# Altium schematic sheet driver0-3.SchDoc(driver0-3)
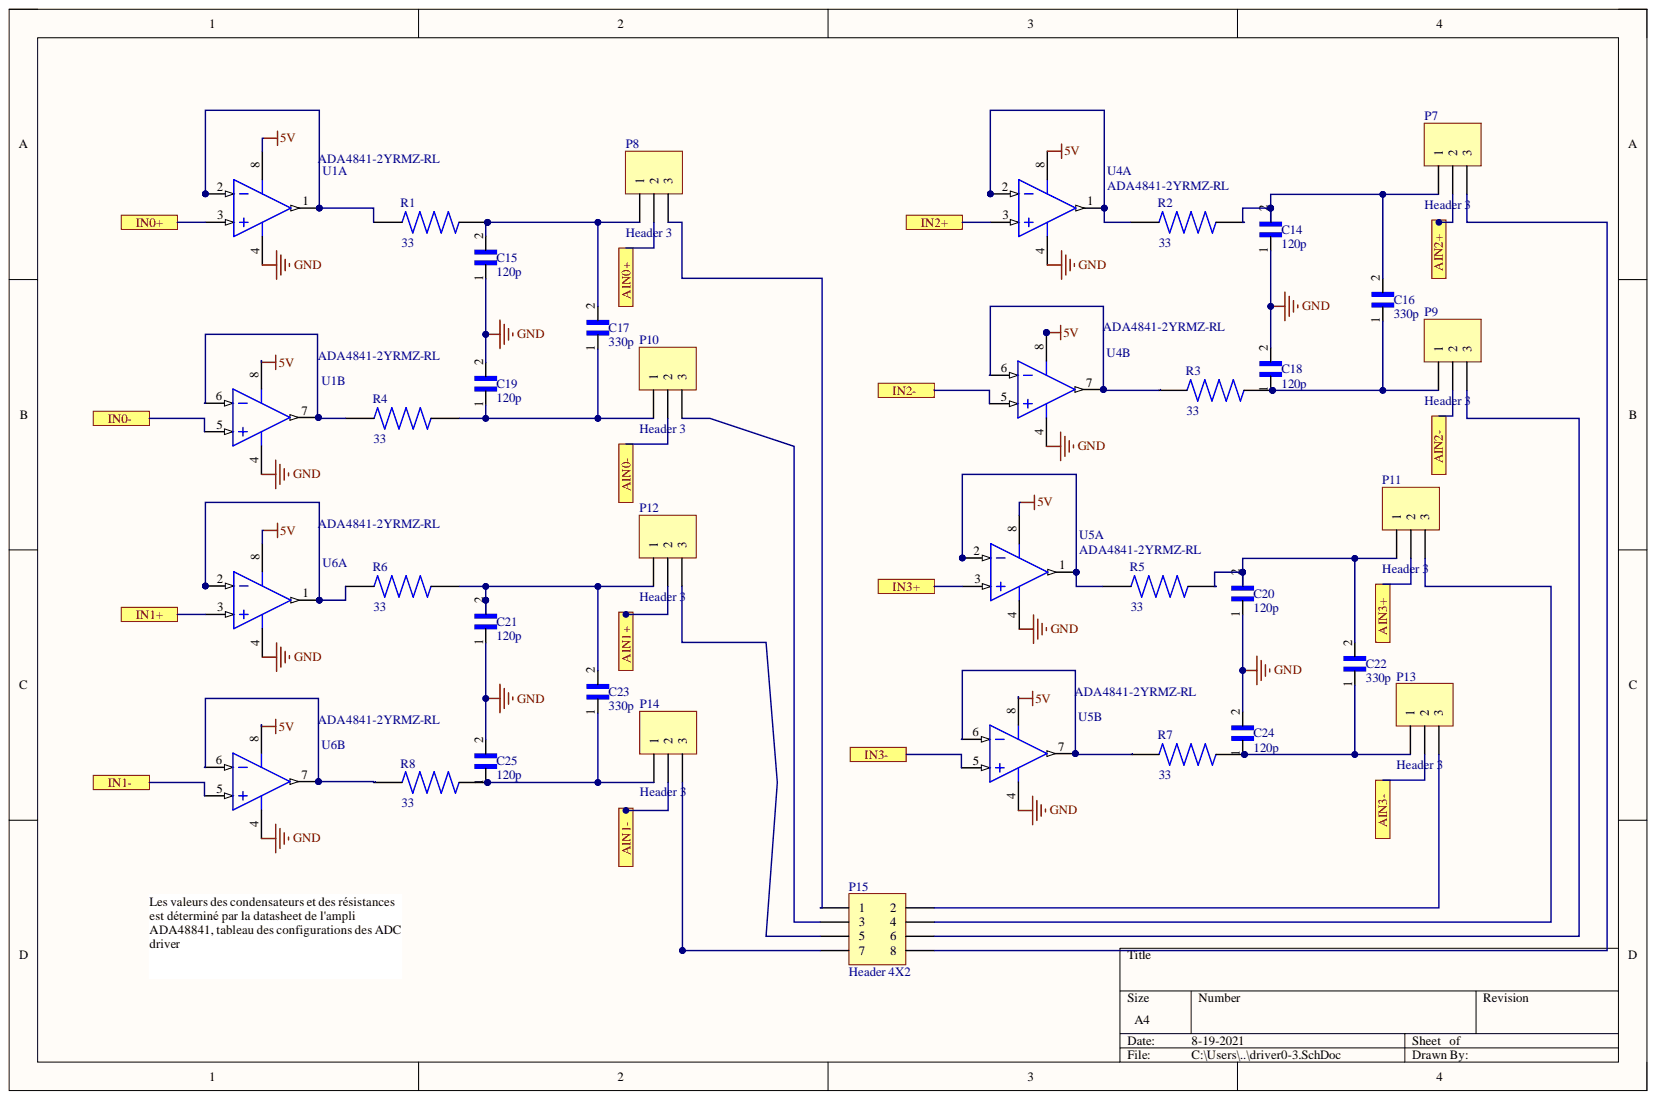
[diagram p0: driver0-3.SchDoc]

## Parts
C14  120p  [CAPC3216X94N]
C15  120p  [CAPC3216X94N]
C16  330p  [CAPC3216X94N]
C17  330p  [CAPC3216X94N]
C18  120p  [CAPC3216X94N]
C19  120p  [CAPC3216X94N]
C20  120p  [CAPC3216X94N]
C21  120p  [CAPC3216X94N]
C22  330p  [CAPC3216X94N]
C23  330p  [CAPC3216X94N]
C24  120p  [CAPC3216X94N]
C25  120p  [CAPC3216X94N]
P7  Header 3  [HDR1X3]
P8  Header 3  [HDR1X3]
P9  Header 3  [HDR1X3]
P10  Header 3  [HDR1X3]
P11  Header 3  [HDR1X3]
P12  Header 3  [HDR1X3]
P13  Header 3  [HDR1X3]
P14  Header 3  [HDR1X3]
P15  Header 4X2  [HDR2X4]
R1  33  [RESC3116X75N]
R2  33  [RESC3116X75N]
R3  33  [RESC3116X75N]
R4  33  [RESC3116X75N]
R5  33  [RESC3116X75N]
R6  33  [RESC3116X75N]
R7  33  [RESC3116X75N]
R8  33  [RESC3116X75N]
U1  ADA4841-2YRMZ-RL  [ADI-RM-8_M]  (parts A,B)
U4  ADA4841-2YRMZ-RL  [ADI-RM-8_M]  (parts A,B)
U5  ADA4841-2YRMZ-RL  [ADI-RM-8_M]  (parts A,B)
U6  ADA4841-2YRMZ-RL  [ADI-RM-8_M]  (parts A,B)

## Ports
AIN0+, AIN0-, AIN1+, AIN1-, AIN2+, AIN2-, AIN3+, AIN3-, IN0+, IN0-, IN1+, IN1-, IN2+, IN2-, IN3+, IN3-

## Nets
5V: U1.8 U4.8 U5.8 U6.8
GND: C14.1 C15.1 C18.2 C19.2 C20.1 C21.1 C24.2 C25.2 U1.4 U4.4 U5.4 U6.4
AIN0+: P8.2 ; port AIN0+
AIN0-: P10.2 ; port AIN0-
AIN1+: P12.2 ; port AIN1+
AIN1-: P14.2 ; port AIN1-
AIN2+: P7.2 ; port AIN2+
AIN2-: P9.2 ; port AIN2-
AIN3+: P11.2 ; port AIN3+
AIN3-: P13.2 ; port AIN3-
IN0+: U1.3 ; port IN0+
IN0-: U1.5 ; port IN0-
IN1+: U6.3 ; port IN1+
IN1-: U6.5 ; port IN1-
IN2+: U4.3 ; port IN2+
IN2-: U4.5 ; port IN2-
IN3+: U5.3 ; port IN3+
IN3-: U5.5 ; port IN3-
NetC14_2: C14.2 C16.2 P7.1 R2.2
NetC15_2: C15.2 C17.2 P8.1 R1.2
NetC16_1: C16.1 C18.1 P9.1 R3.2
NetC17_1: C17.1 C19.1 P10.1 R4.2
NetC20_2: C20.2 C22.2 P11.1 R5.2
NetC21_2: C21.2 C23.2 P12.1 R6.2
NetC22_1: C22.1 C24.1 P13.1 R7.2
NetC23_1: C23.1 C25.1 P14.1 R8.2
NetP7_3: P7.3 P15.8
NetP8_3: P8.3 P15.1
NetP9_3: P9.3 P15.6
NetP10_3: P10.3 P15.3
NetP11_3: P11.3 P15.4
NetP12_3: P12.3 P15.5
NetP13_3: P13.3 P15.2
NetP14_3: P14.3 P15.7
NetR1_1: R1.1 U1.1 U1.2
NetR2_1: R2.1 U4.1 U4.2
NetR3_1: R3.1 U4.6 U4.7
NetR4_1: R4.1 U1.6 U1.7
NetR5_1: R5.1 U5.1 U5.2
NetR6_1: R6.1 U6.1 U6.2
NetR7_1: R7.1 U5.6 U5.7
NetR8_1: R8.1 U6.6 U6.7

## Library data of the parts
C14 C15 C18-C21 C24 C25 = 12061A121JAT2A: CERAMIC CAPACITOR 120PF 100V, C0G, 5%, 1206; MF: {'manufacturer': u'AVX'}; Availability: Unavailable; Component Kind: Standard; Library Name: 12061A121JAT2A.SchLib; MP: 12061A121JAT2A; Package: None; Price: None
C16 C17 C22 C23 = 12065A331JAT2A: Ceramic Multilayer Capacitor; Capacitor Type:General Purpose; Capacitance:330pF; Capacitance Tolerance:Â± 5%; Voltage Rating:50VDC; Capacitor Dielectric Type:Multilayer Ceramic; Package/Case:1206; Termination Type:SMD ;RoHS Compliant: Yes; MF: {'manufacturer': u'AVX'}; Availability: Unavailable; Component Kind: Standard; Library Name: 12065A331JAT2A.SchLib; MP: 12065A331JAT2A; Package: None; Price: None
P7-P14 = Header 3: Header, 3-Pin; Component Kind: Standard; LatestRevisionDate: 17-Jul-2002; LatestRevisionNote: Re-released for DXP Platform.; Library Name: Miscellaneous Connectors.IntLib; Signal Integrity: Connector; Publisher: Altium Limited
P15 = Header 4X2: Header, 4-Pin, Dual row; Component Kind: Standard; LatestRevisionDate: 17-Jul-2002; LatestRevisionNote: Re-released for DXP Platform.; Library Name: Miscellaneous Connectors.IntLib; Signal Integrity: Connector; Publisher: Altium Limited
R1-R8 = RTAN1206BKE33R0: MF: {'manufacturer': u'Stackpole Electronics Inc'}; Availability: Unavailable; Component Kind: Standard; Library Name: RTAN1206BKE33R0.SchLib; MP: RTAN1206BKE33R0; Package: None; Price: None
U1 U4-U6 = CMP-0384-01677-1: Double Channel Low Wide Band Noise Operational Amplifier, 80 MHz BW, 13 V/us SR, Industrial, 8-pin SOP (RM-8), Reel; Manufacturer: Analog Devices; Amplifiers Per Package: 2; Input Bias Current: 3uA; Input Offset Voltage: 40uV; Iq/Amp: 1.5mA; Slew Rate: 13V/us; Small Signal Bandwidth: 80MHz; V Span Max: 12V; V Span Min: 2.7V; Code_JEDEC: MO-187-AA; Component Kind: Standard; ComponentLink1Description: Manufacturer URL; ComponentLink1URL: http://www.analog.com/en/index.html; ComponentLink2Description: Datasheet; ComponentLink2URL: http://www.analog.com/static/imported-files/data_sheets/ADA4841-1_4841-2.pdf; ComponentLink3Description: Package Specification; ComponentLink3URL: http://www.analog.com/static/imported-files/packages/PKG_PDF/MSOP%28RM%29/RM_8.pdf; DatasheetVersion: Rev. E; Generic Part Number: ADA4841-2; Library Name: Altium Content Vault; Mounting Technology: Surface Mount; PackageDescription: 8-Pin Mini Small Outline Package, Body 3 x 3 mm, Pitch 0.65 mm; PackageReference: RM-8; PartNumber: ADA4841-2YRMZ-RL
